# Altium schematic sheet SAMA7D65_PMIC.SchDoc(SAMA7D65_PMIC)
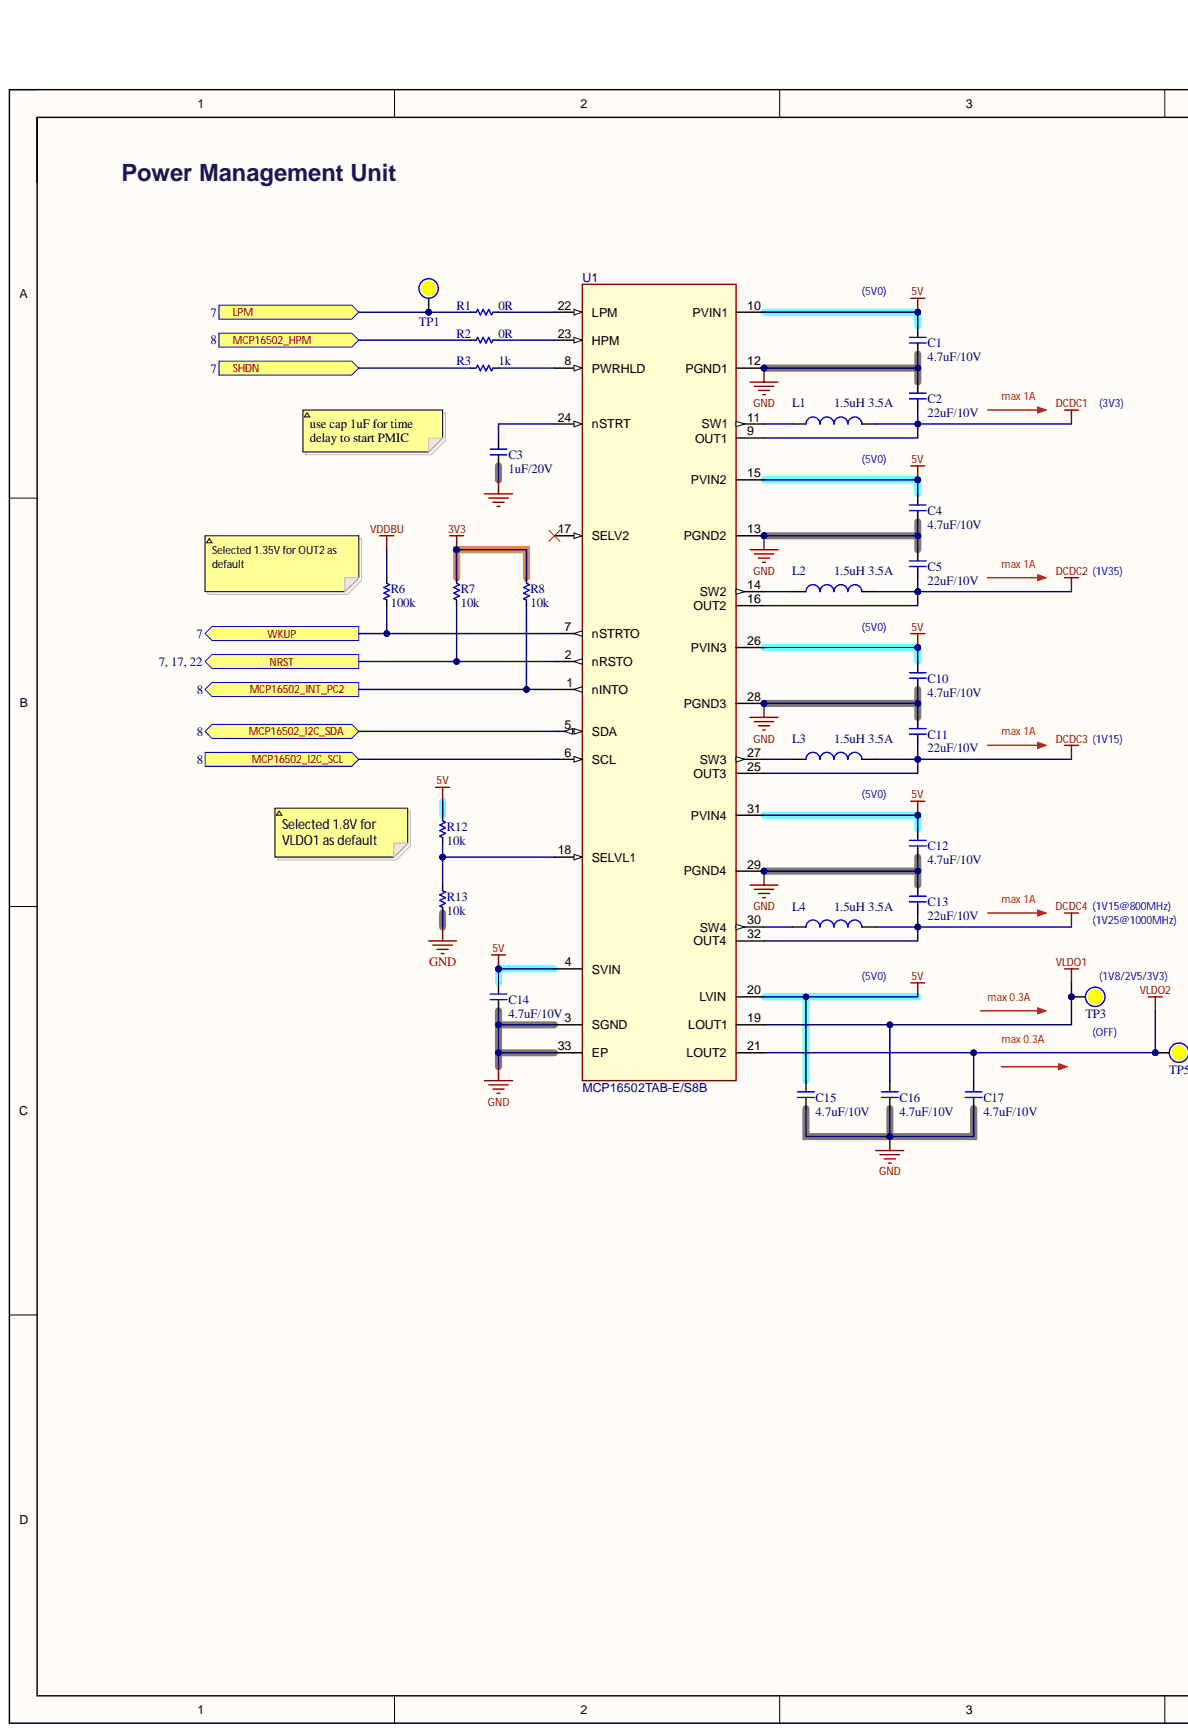
[diagram p0: SAMA7D65_PMIC.SchDoc, left]
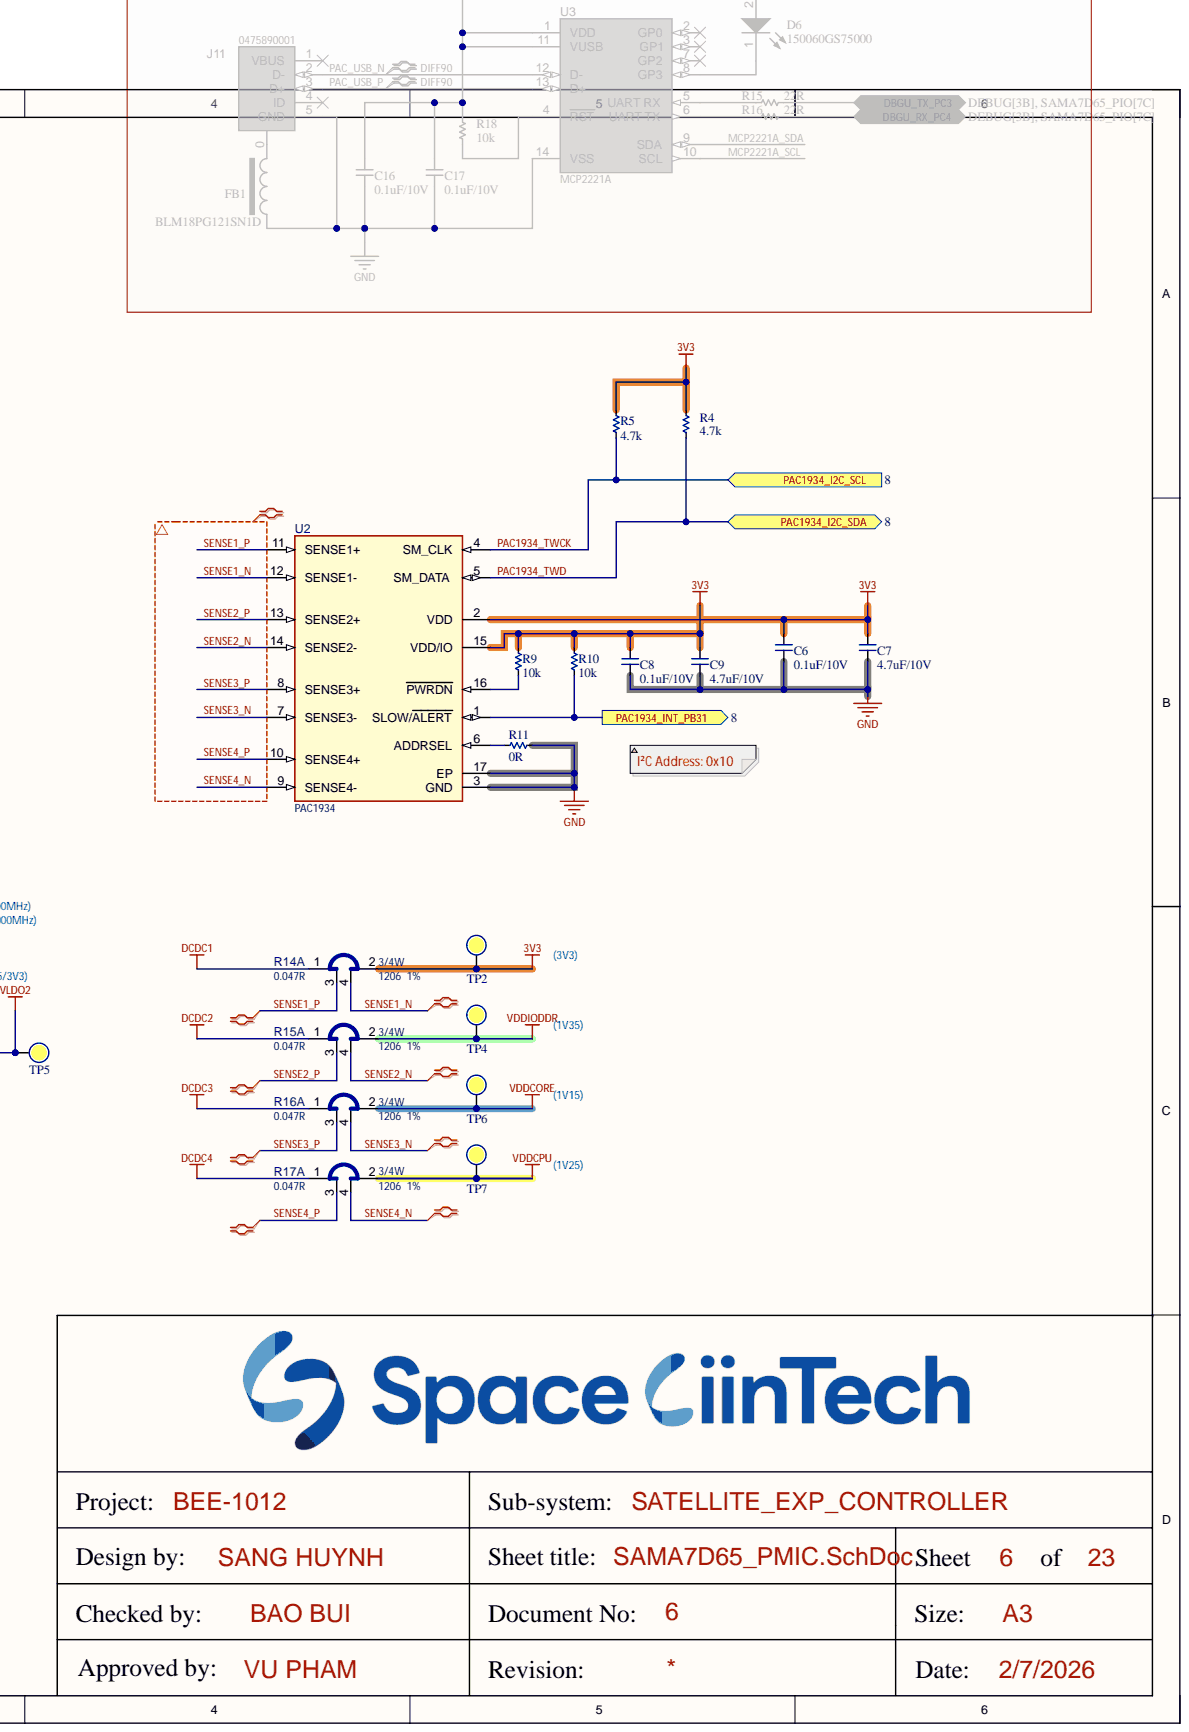
[diagram p1: SAMA7D65_PMIC.SchDoc, right]

## Parts
C1  4.7uF/10V  [CAP_C_1005X50_L]
C2  22uF/10V  [CAP_C_1608X80]
C3  1uF/20V  [CAP_C_1005X50_L]
C4  4.7uF/10V  [CAP_C_1005X50_L]
C5  22uF/10V  [CAP_C_1608X80]
C6  0.1uF/10V  [CAP_C_1005X50_L]
C7  4.7uF/10V  [CAP_C_1005X50_L]
C8  0.1uF/10V  [CAP_C_0603x30]
C9  4.7uF/10V  [CAP_C_0603x30]
C10  4.7uF/10V  [CAP_C_1005X50_L]
C11  22uF/10V  [CAP_C_1608X80]
C12  4.7uF/10V  [CAP_C_1005X50_L]
C13  22uF/10V  [CAP_C_1608X80]
C14  4.7uF/10V  [RES_C_0603X30]
C15  4.7uF/10V  [CAP_C_1005X50_L]
C16  4.7uF/10V  [CAP_C_1005X50_L]
C17  4.7uF/10V  [CAP_C_1005X50_L]
L1  1.5uH 3.5A  [IND_C_1008]
L2  1.5uH 3.5A  [IND_C_1008]
L3  1.5uH 3.5A  [IND_C_1008]
L4  1.5uH 3.5A  [IND_C_1008]
R1  0R  [RES_C_0603X30]
R2  0R  [RES_C_0603X30]
R3  1k  [RES_C_0603X30]
R4  4.7k  [RES_C_1005x30_L]
R5  4.7k  [RES_C_1005x30_L]
R6  100k  [RES_C_0603X30]
R7  10k  [RES_C_0603X30]
R8  10k  [RES_C_0603X30]
R9  10k  [RES_C_1005x30_L]
R10  10k  [RES_C_1005x30_L]
R11  0R  [RES_C_0603X30]
R12  10k  [RES_C_1005x30_L]
R13  10k  [RES_C_1005x30_L]
R14  value 0.047R  Package: 1206  Power: 3/4W  Tolerance: 1%  [RES_C_1206_SHUNT]  (hidden comment: Shunt 4 Pin)  (part A)
R15  value 0.047R  Package: 1206  Power: 3/4W  Tolerance: 1%  [RES_C_1206_SHUNT]  (hidden comment: Shunt 4 Pin)  (part A)
R16  value 0.047R  Package: 1206  Power: 3/4W  Tolerance: 1%  [RES_C_1206_SHUNT]  (hidden comment: Shunt 4 Pin)  (part A)
R17  value 0.047R  Package: 1206  Power: 3/4W  Tolerance: 1%  [RES_C_1206_SHUNT]  (hidden comment: Shunt 4 Pin)  (part A)
TP1  (no value)  [Test point]  (no BOM)
TP2  (no value)  [Test point]  (no BOM)
TP3  (no value)  [Test point]  (no BOM)
TP4  (no value)  [Test point]  (no BOM)
TP5  (no value)  [Test point]  (no BOM)
TP6  (no value)  [Test point]  (no BOM)
TP7  (no value)  [Test point]  (no BOM)
U1  value MCP16502TAB-E/S8B  Manufacturer Part Number 1: MCP16502TAB-E/S8B  [QFN-32_5X5_EP3.7X3.7]  (hidden comment: MCP16502 QFN-32)
U2  value PAC1934  [UQFN-16_4X4_EP2.6X2.6]  (hidden comment: Microchip PAC1934 UQFN-16)

## Ports
LPM, MCP16502_HPM, MCP16502_I2C_SCL, MCP16502_I2C_SDA, MCP16502_INT_PC2, NRST, PAC1934_I2C_SCL, PAC1934_I2C_SDA, PAC1934_INT_PB31, SHDN, WKUP

## Nets
3V3: C6.2 C7.2 C8.2 C9.2 R4.1 R5.1 R7.2 R8.2 R9.2 R10.2 R14.2 TP2.0 U2.2(VDD) U2.15(VDD/IO)
5V: C1.2 C4.2 C10.2 C12.2 C14.2 C15.2 R12.2 U1.4(SVIN) U1.10(PVIN1) U1.15(PVIN2) U1.20(LVIN) U1.26(PVIN3) U1.31(PVIN4)
DCDC1: C2.1 L1.2 R14.1 U1.9(OUT1)
DCDC2: C5.1 L2.2 R15.1 U1.16(OUT2)
DCDC3: C11.1 L3.2 R16.1 U1.25(OUT3)
DCDC4: C13.1 L4.2 R17.1 U1.32(OUT4)
GND: C1.1 C2.2 C3.1 C4.1 C5.2 C6.1 C7.1 C8.1 C9.1 C10.1 C11.2 C12.1 C13.2 C14.1 C15.1 C16.1 C17.1 R11.2 R13.1 U1.3(SGND) U1.12(PGND1) U1.13(PGND2) U1.28(PGND3) U1.29(PGND4) U1.33(EP) U2.3(GND) U2.17(EP)
VDDBU: R6.2
VDDCORE: R16.2 TP6.0
VDDCPU: R17.2 TP7.0
VDDIODDR: R15.2 TP4.0
VLDO1: C16.2 TP3.0 U1.19(LOUT1)
VLDO2: C17.2 TP5.0 U1.21(LOUT2)
LPM: R1.1 TP1.0 ; port LPM
MCP16502_HPM: R2.1 ; port MCP16502_HPM
MCP16502_I2C_SCL: U1.6(SCL) ; port MCP16502_I2C_SCL
MCP16502_I2C_SDA: U1.5(SDA) ; port MCP16502_I2C_SDA
MCP16502_INT_PC2: R8.1 U1.1(nINTO) ; port MCP16502_INT_PC2
NRST: R7.1 U1.2(nRSTO) ; port NRST
PAC1934_INT_PB31: R10.1 U2.1(SLOW/ALERT) ; port PAC1934_INT_PB31
PAC1934_TWCK: R5.2 U2.4(SM_CLK) ; port PAC1934_I2C_SCL
PAC1934_TWD: R4.2 U2.5(SM_DATA) ; port PAC1934_I2C_SDA
SENSE1_N: R14.4 U2.12(SENSE1-)
SENSE1_P: R14.3 U2.11(SENSE1+)
SENSE2_N: R15.4 U2.14(SENSE2-)
SENSE2_P: R15.3 U2.13(SENSE2+)
SENSE3_N: R16.4 U2.7(SENSE3-)
SENSE3_P: R16.3 U2.8(SENSE3+)
SENSE4_N: R17.4 U2.9(SENSE4-)
SENSE4_P: R17.3 U2.10(SENSE4+)
SHDN: R3.1 ; port SHDN
WKUP: R6.1 U1.7(nSTRTO) ; port WKUP
NetC3_2: C3.2 U1.24(nSTRT)
NetL1_1: L1.1 U1.11(SW1)
NetL2_1: L2.1 U1.14(SW2)
NetL3_1: L3.1 U1.27(SW3)
NetL4_1: L4.1 U1.30(SW4)
NetR1_2: R1.2 U1.22(LPM)
NetR2_2: R2.2 U1.23(HPM)
NetR3_2: R3.2 U1.8(PWRHLD)
NetR9_1: R9.1 U2.16(PWRDN)
NetR11_1: R11.1 U2.6(ADDRSEL)
NetR12_1: R12.1 R13.2 U1.18(SELVL1)

Not connected: U1 17(SELV2)

## Library data of the parts
C1-C17 = _CAP_C_2: CAP CHIP; Component Kind: Standard; Library Name: IFBschelib.SchLib
L1-L4 = DFE252012P-1R5M=P2: Fixed Inductor 1.5uH 3.5A R060 SMD; Availability: Unavailable; Component Kind: Standard; MP: DFE252012P-1R5M=P2; Package: None; Price: None; Library Name: IFBschelib.SchLib
R1-R13 = _RES_B_2: RES; Component Kind: Standard; Library Name: IFBschelib.SchLib
R14-R17 = SHUNT: Shunt Resistor, RES 0.047 OHM 1% 3/4W 1206; Manufacturer 1: SUSUMU; Manufacturer Part Number 1: KRL1632E-M-R047-F-T5; Current: 4A; Description_: RES SHUNT MF 0.047R 1% 3/4W SMD 1206; Dev Tools Status: Design; MCHP-ID: RSMT1405; Owner: Ciprian Abramov; Populated: YES; Restricted Sourcing: No; Temperature Coefficient: ±50ppm/C; Category: Resistor; Component Kind: Standard; ComponentLink1Description: SVN Datasheet; ComponentLink1URL: https://chn-vm-apps.microchip.com/mchprepo/parts/Datasheets/n_catalog_partition09_en.pdf; ComponentLink2Description: Local Datasheet; ComponentLink3Description: Open Symbol; ComponentLink4Description: Open Footprint; ComponentLink5Description: Open Footprint 2; ComponentLink6Description: Open Footprint 3; ComponentLink7Description: Open Footprint 4; Composition: MF; Operating Temperature: -55C to 155C; Quantity Override: 1; Revision: 1; Status: MCL Design; Supplier 1: Digi-Key; Supplier Part Number 1: 408-1578-2-ND; Symbol: Shunt 4 Pin; Type: Shunt; ComponentLink2URL: C:\ALTIUM_WORK\00001_MCHP-CDB\Datasheets\n_catalog_partition09_en.pdf; ComponentLink3URL: C:\ALTIUM_WORK\00001_MCHP-CDB\Library\LibraryRefs\Shunt 4 Pin.SchLib; ComponentLink4URL: C:\ALTIUM_WORK\00001_MCHP-CDB\Library\Footprints\RES_C_1206_SHUNT.PcbLib; Library Name: IFBschelib.SchLib
TP1-TP7 = Test Point: Solder: NF; Description: Test Point; Library Name: IFBschelib.SchLib
U1 = MIC8878: Manufacturer 1: Microchip Technology; Description_: MCHP ANALOG PMIC SWITCHER Buck ADJ MCP16502TAB-E/S8B QFN-32 for SAMA7G5 MPU with LPDDR/DDR2/DDR3; Dev Tools Status: Design; Owner: Dragos Danaila; Populated: YES; Category: MCHP-Analog; Component Kind: Standard; ComponentLink1Description: SVN Datasheet; ComponentLink2Description: Local Datasheet; ComponentLink3Description: Open Symbol; ComponentLink4Description: Open Footprint; ComponentLink5Description: Open Footprint 2; ComponentLink6Description: Open Footprint 3; ComponentLink7Description: Open Footprint 4; MCHP-ID: MIC8878; Quantity Override: 1; Revision: 1; Status: MCL Design; Supplier 1: Digi-Key; Supplier Part Number 1: 150-MCP16502TAB-E/S8BCT-ND; Symbol: MCP16502 QFN-32; Type: Switcher; ComponentLink2URL: C:\ALTIUM_WORK\00001_MCHP-CDB\Datasheets\MCP16502-High-Performance-PMIC-for-SAMA5DX-SAM9X6-MPUs-Data-Sheet-DS20006275B.pdf; ComponentLink3URL: C:\ALTIUM_WORK\00001_MCHP-CDB\Library\LibraryRefs\MCP16502 QFN-32.SchLib; ComponentLink4URL: C:\ALTIUM_WORK\00001_MCHP-CDB\Library\Footprints\QFN-32_5X5_EP3.7X3.7.PcbLib; Library Name: IFBschelib.SchLib
U2 = PAC1934T-I/JQ: IC CURRENT MONITOR 1% 16UQFN; Manufacturer 1: Microchip Technology; Description_: MCHP ANALOG POWER CURRENT SENSE MONITOR PAC1934T-I/JQ UQFN-16; Dev Tools Status: Production; MCHP-ID: MIC7010; Owner: Razvan Cristescu; Populated: YES; Restricted Sourcing: No; Category: MCHP-Analog; Component Kind: Standard; ComponentLink1Description: SVN Datasheet; ComponentLink1URL: https://www.microchip.com/sitesearch/search/Product%20and%20Development%20Tools/PAC1934T-I/JQ; ComponentLink2Description: Local Datasheet; ComponentLink3Description: Open Symbol; ComponentLink4Description: Open Footprint; ComponentLink5Description: Open Footprint 2; ComponentLink6Description: Open Footprint 3; ComponentLink7Description: Open Footprint 4; Manufacturer Part Number 1: PAC1934T-I/JQ; Operating Temperature: -40C to +85C; Quantity Override: 1; Revision: 2; Status: MCL Design; Supplier 1: Microchip Technology; Supplier Part Number 1: PAC1934T-I/JQ; Symbol: PAC1934 UQFN-16; Type: Power/Current Sensor; ComponentLink3URL: C:\ALTIUM_WORK\00001_MCHP-CDB\Library\LibraryRefs\PAC1934 UQFN-16.SchLib; ComponentLink4URL: C:\ALTIUM_WORK\00001_MCHP-CDB\Library\Footprints\UQFN-16_4X4_EP2.6X2.6.PcbLib; Library Name: IFBschelib.SchLib
